# Altium schematic sheet Load.SchDoc
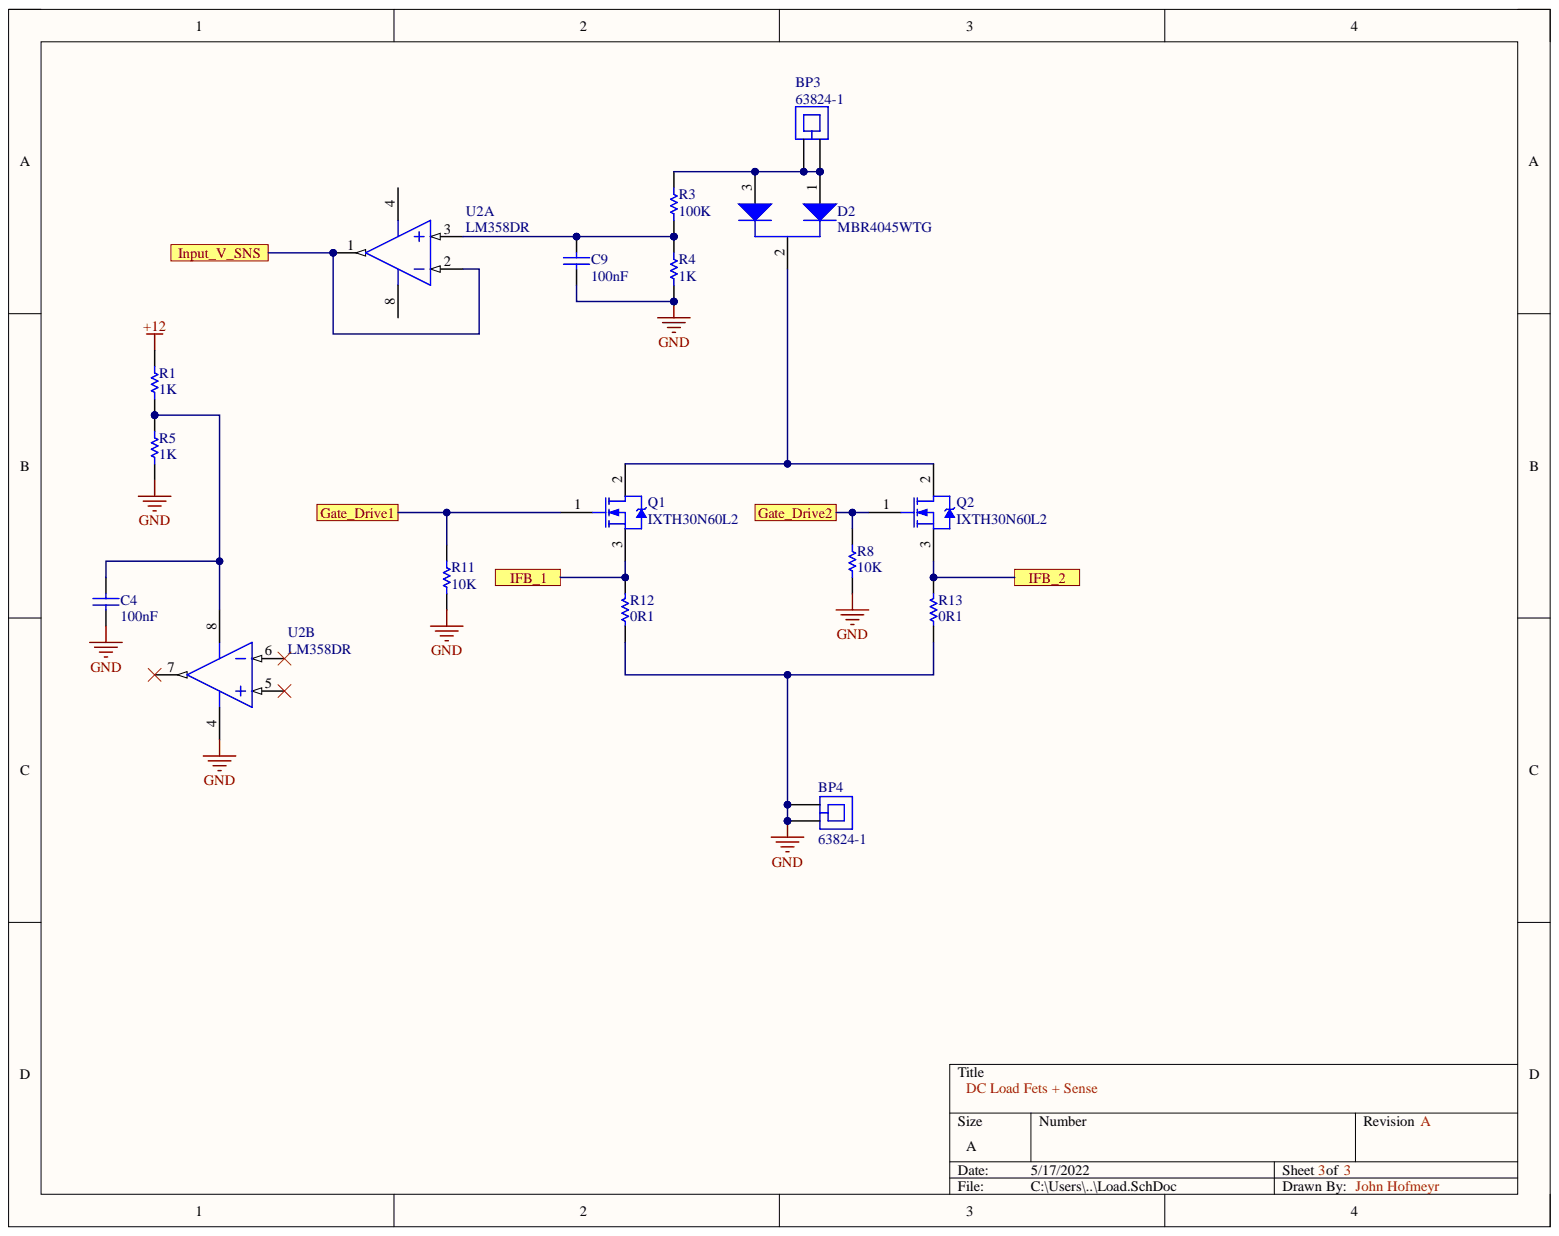
[diagram p0: Load.SchDoc]

## Parts
BP3  63824-1  [TECO-63824-1_V]
BP4  63824-1  [TECO-63824-1_V]
C4  100nF  [CAPC2013X140X50ML20T25]
C9  100nF  [CAPC2013X140X50ML20T25]
D2  MBR4045WTG  [ONSC-TO-247-3-340L-02]
Q1  IXTH30N60L2  [INF-PG-TO247-3-44]
Q2  IXTH30N60L2  [INF-PG-TO247-3-44]
R1  1K  [RESC0805(2012)_M]
R3  100K  [RESC2013X50X40NL20T20]
R4  1K  [RESC2013X50X40NL20T20]
R5  1K  [RESC0805(2012)_M]
R8  10K  [RESC2013X50X40NL20T20]
R11  10K  [RESC2013X50X40NL20T20]
R12  0R1  [Res_TO-247]
R13  0R1  [Res_TO-247]
U2  LM358DR  [D0008A_L]  (parts A,B)

## Ports
Gate_Drive1, Gate_Drive2, IFB_1, IFB_2, Input_V_SNS

## Nets
+12: R1.2
GND: BP4.1 BP4.2 C4.1 C9.1 R4.1 R5.1 R8.1 R11.1 R12.1 R13.1 U2.4
Gate_Drive1: Q1.1 R11.2 ; port Gate_Drive1
Gate_Drive2: Q2.1 R8.2 ; port Gate_Drive2
IFB_1: Q1.3 R12.2 ; port IFB_1
IFB_2: Q2.3 R13.2 ; port IFB_2
Input_V_SNS: U2.1 U2.2 ; port Input_V_SNS
NetBP3_1: BP3.1 BP3.2 D2.1 D2.3 R3.2
NetC4_2: C4.2 R1.1 R5.2 U2.8
NetC9_2: C9.2 R3.1 R4.2 U2.3
NetD2_2: D2.2 Q1.2 Q2.2

Not connected: U2 5 6 7

## Library data of the parts
BP3 BP4 = CMP-1684-00002-4: Printed Circuit Board Terminal and Disconnect, 2-Pin THD, RoHS, Tape and Reel; Manufacturer: TE Connectivity; Colour: Silver; Component Kind: Standard; ComponentLink1Description: Manufacturer URL; ComponentLink1URL: http://www.te.com/; ComponentLink2Description: Datasheet; ComponentLink2URL: http://www.te.com/commerce/DocumentDelivery/DDEController?Action=showdoc&DocId=Customer+Drawing%7F63824%7FR%7Fpdf%7FEnglish%7FENG_CD_63824_R_baseFilename.pdf%7F63824-1; Contact Material: Brass; Contact Plating: Tin; DatasheetVersion: Rev. R, 06/2013; Length: 13.63 mm; Library Name: Altium Content Vault; Mounting Technology: Through Hole; Package Quantity: 10000; PackageDescription: 2-Pin Through Hole Device, Body 7.92 x 3.08 mm, Pitch 5.08 mm; PackageReference: 63824-1; Packaging: Tape and Reel; REACH SVHC: Unknown; RoHSCompliant: True
C4 C9 = CMP-2007-04689-1: Manufacturer: AVX Interconnect / Elco; Part Number: 08055D104KAT2A; Component Kind: Standard; Library Name: Altium Content Vault
D2 = CMP-1055-00714-1: SWITCHMODE(TM) Power Rectifier, 3-Pin TO-247, Pb-Free, Tube; Manufacturer: On Semiconductor; Configuration: Common Cathode; IFSM Max (A): 400; Component Kind: Standard; ComponentLink1Description: Manufacturer URL; ComponentLink1URL: http://www.onsemi.com/; ComponentLink2Description: Datasheet; ComponentLink2URL: http://www.onsemi.cn/pub_link/Collateral/MBR4045WT-D.PDF; ComponentLink3Description: Package Specification; ComponentLink3URL: http://www.onsemi.cn/pub_link/Collateral/340L-02.PDF; DatasheetVersion: Rev. 8; Library Name: Altium Content Vault; Mounting Technology: Through Hole; PackageDescription: 3-Pin Flange Mount, Vertical Straight Lead, Body 16.005 x 5 mm, Pitch 5.45 mm; PackageReference: TO-247-3-340L-02; PackageVersion: Rev. F, 10/2011; Packing: Tube; PartNumber: MBR4045WTG; RoHS: TRUE
Q1 Q2 = CMP-1164-00216-1: N-Channel CoolMOS Power-Transistor, 600 V VDS, 20.7 A ID, -55 to 150 degC, PG-TO247-3-44, Tube, Green; Manufacturer: Infineon; PartNumber: SPW20N60C3; Green: TRUE; ID (max): 20.7Â A; IDpuls (max): 62.1Â A; Polarity: N; Ptot (max): 208Â W; QG: 87Â nC; RDS (on) (max): 190Â mOhm; Rth: 0.6Â K/W; RthJA (max): 62Â K/W; VDS (max): 600Â V; VGS(th) (max): 3.9Â V; VGS(th) (min): 2.1Â V; Component Kind: Standard; ComponentLink1Description: Manufacturer URL; ComponentLink1URL: http://www.infineon.com/; ComponentLink2Description: Datasheet; ComponentLink2URL: http://www.infineon.com/dgdl/SPW20N60C3_Rev.2.5_PCN_.pdf?folderId=db3a304412b407950112b408e8c90004&fileId=db3a304412b407950112b42e3702497f; ComponentLink3Description: Package Specification; ComponentLink3URL: http://www.infineon.com/cms/packages/Leaded_and_through-hole/P-PG-TO247/P-PG-TO247-3-44.html?__locale=en; DatasheetVersion: Rev. 2.5; Halogen-free: available; Library Name: Altium Content Vault; Mounting Technology: Through Hole; PackageDescription: 3-Pin Plastic (Green) Transistor Single Outline Package, 15.94 x 20.95 mm Body, 5.44 mm Pitch; PackageReference: PG-TO247-3-44; PackageVersion: Rev. 03; Packing: Tube; RthJC (max): 0.6Â K/W; Technology: CoolMOS C3
R1 R5 = CMP-1013-00510-1: 1K 0.125W 1% 0805 (2012 Metric)  SMD; Case-EIA: 0805; Case-Metric: 2012; Value: 1000.00 Ohm; Component Kind: Standard; Library Name: Altium Content Vault; Power: 0.125 W; Technology: SMT; Tolerance: 1 %
R3 R4 R8 R11 = CMP-2001-03215-1: Manufacturer: Susumu; Part Number: RR1220P-103-D; Component Kind: Standard; Library Name: Altium Content Vault
R12 R13 = FPR2A-0R1F1: Res 100 Mohm 30W 1% TO218; Manufacturer: Riedon; Resistance: 100mR; Case/Package: TO-247-2; Category: Through-Hole Resistors; Component Kind: Standard; ComponentLink1Description: http://riedon.com/media/pdf/FPR2T218.pdf?src-supplier=Digi-Key; ComponentLink1URL: http://riedon.com/media/pdf/FPR2T218.pdf?src-supplier=Digi-Key; Height: 0.839inch; Length: 0.61inch; Library Name: MainBoard.SchLib; Manufacturer Part Number: FPR2A-0R1F1; Max Operating Temperature: 130°C; Max Power Dissipation: 30W; Min Operating Temperature: -40°C; Number of Terminations: 2; Package Quantity: 200; Packaging: Bulk; Pricing 1: 1=6.36000, 10=5.96700, 25=5.56920, 50=4.90620, 100=4.24320, 250=3.84540, 500=3.58020, 1000=3.31500, 5000=3.18240 (USD); Resistor Type: Metal Foil; RoHS: Yes; Stock 1: 1001; Supplier 1: Digi-Key; Supplier Part Number 1: 696-1004-ND; Tolerance: 1%; Width: 0.181inch
U2 = CMP-1685-00009-1: Dual Operational Amplifier, 3 to 32 V, 0 to 70 degC, 8-Pin SOIC (D), Green (RoHS & no Sb/Br), Tape and Reel; Code_JEDEC: MS-012; Component Kind: Standard; ComponentLink1Description: Manufacturer URL; ComponentLink1URL: http://www.ti.com/; ComponentLink2Description: Datasheet; ComponentLink2URL: http://www.ti.com/lit/ds/symlink/lm358.pdf; ComponentLink3Description: Package Specification; ComponentLink3URL: http://www.ti.com/lit/ml/msoi002j/msoi002j.pdf; DatasheetVersion: SLOS068T, 04/2015; Library Name: Altium Content Vault; PackageDescription: 8-Pin Small Outline Integrated Circuit, Body 4.9 x 3.9 mm, Pitch 1.27 mm; PackageReference: D0008A; PackageVersion: 4040047-3/M, 06/2011
